# Altium schematic sheet Buttons.SchDoc(Buttons)
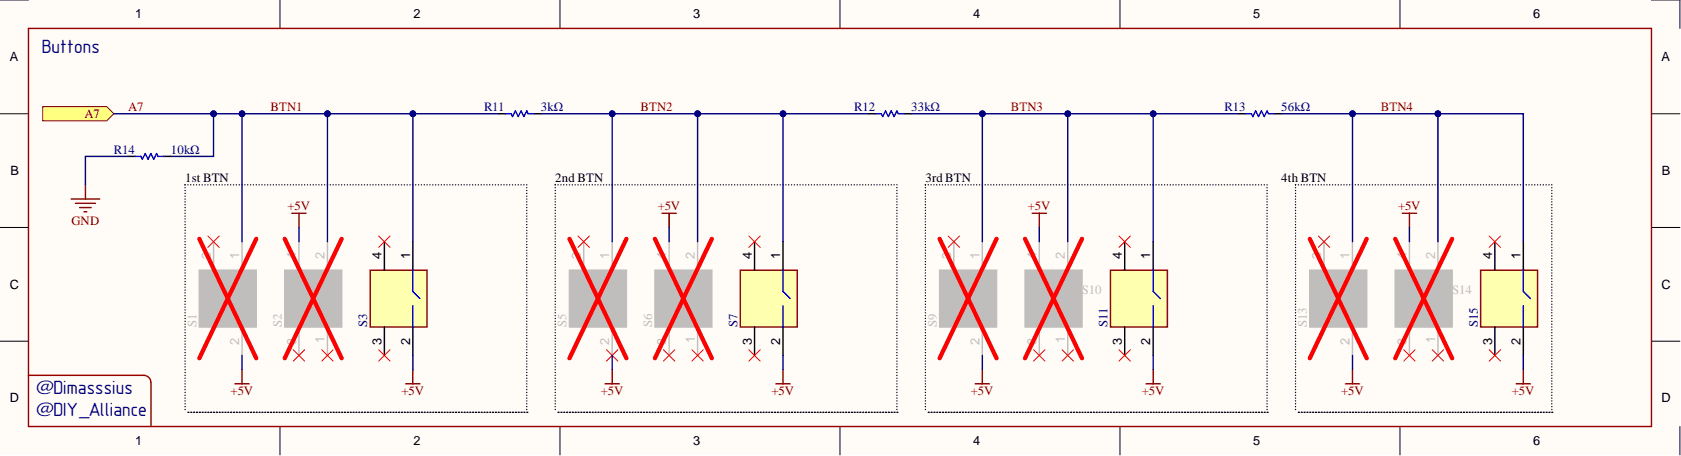
[diagram p0: Buttons.SchDoc]

## Parts
R11  3kΩ  [RES_THD/SMD_R0603_R0402_3K_THD]
R11
R11
R12  33kΩ  [RES_THD/SMD_R0603_R0402_33K_THD]
R12
R12
R13  56kΩ  [RES_THD/SMD_R0603_R0402_56K_THD]
R13
R13
R14  10kΩ  [RES_THD/SMD_R0603_R0402_10K_THD]
R14
R14
S1  TS-1009B  [TS-1009B]  (value not drawn)
S2  TS-1187A-B-A-B  [TS-1187A-B-A-B]  (value not drawn)
S3  EVQPF108K  [EVQPF008K]  (value not drawn)
S5  TS-1009B  [TS-1009B]  (value not drawn)
S6  TS-1187A-B-A-B  [TS-1187A-B-A-B]  (value not drawn)
S7  EVQPF108K  [EVQPF008K]  (value not drawn)
S9  TS-1009B  [TS-1009B]  (value not drawn)
S10  TS-1187A-B-A-B  [TS-1187A-B-A-B]  (value not drawn)
S11  EVQPF108K  [EVQPF008K]  (value not drawn)
S13  TS-1009B  [TS-1009B]  (value not drawn)
S14  TS-1187A-B-A-B  [TS-1187A-B-A-B]  (value not drawn)
S15  EVQPF108K  [EVQPF008K]  (value not drawn)

## Ports
A7

## Nets
+5V: S1.2 S2.4 S3.2 S5.2 S6.4 S7.2 S9.2 S10.4 S11.2 S13.2 S14.4 S15.2
GND: R14.2
A7: R11.2 R14.1 S1.1 S2.2 S3.1 ; port A7
BTN2: R11.1 R12.2 S5.1 S6.2 S7.1
BTN3: R12.1 R13.2 S9.1 S10.2 S11.1
BTN4: R13.1 S13.1 S14.2 S15.1

Not connected: S1 3; S2 1 3; S3 3 4; S5 3; S6 1 3; S7 3 4; S9 3; S10 1 3; S11 3 4; S13 3; S14 1 3; S15 3 4

## Library data of the parts
R11 = RES_THD/SMD_3KΩ_R0603_R0402_THD: Resistor, Metal Film Resistor, Through Hole, D2.3xL6.5mm, 3kΩ, ±1%, 250mW, Through Hole Resistors, ROHS; Component Kind: Standard; Library Name: Resistors.SchLib; Value: 3kΩ
R12 = RES_THD/SMD_33KΩ_R0603_R0402_THD: Resistor, Metal Film Resistor, Through Hole, D2.3xL6.5mm, 33kΩ, ±1%, 250mW, Through Hole Resistors, ROHS; Component Kind: Standard; Library Name: Resistors.SchLib; Value: 33kΩ
R13 = RES_THD/SMD_56KΩ_R0603_R0402_THD: Resistor, Metal Film Resistor, Through Hole, D2.3xL6.5mm, 56kΩ, ±1%, 250mW, Through Hole Resistors, ROHS; Component Kind: Standard; Library Name: Resistors.SchLib; Value: 56kΩ
R14 = RES_THD/SMD_10KΩ_R0603_R0402_THD: Resistor, Metal Film Resistor, Through Hole, D2.3xL6.5mm, 10kΩ, ±1%, 250mW, Through Hole Resistors, ROHS; Component Kind: Standard; Library Name: Resistors.SchLib; Value: 10kΩ
S1 S5 S9 S13 = TS-1009B: Switch Tactile, Through Hole, 4.5x4.5mm, Round Button, 50mA, Bend-in 4.5mm, SPST, 12V, Plugin, Tactile Switches, ROHS; Component Kind: Standard; Datasheet Link: https://www.mouser.com/datasheet/2/140/TL59-TL58-346345-1369262.pdf; Height: 5mm; Library Name: SamacSys.SchLib; Value: TS-1009B
S2 S6 S10 S14 = TS-1187A-B-A-B: Switch Tactile, SMD, 5.1x5.1mm, Without bracket, 50mA, 100000 Times, 160gf, 12V, Round, SMD Tactile Switches, ROHS; Component Kind: Standard; Library Name: SamacSys.SchLib; Package: None; Value: TS-1187A-B-A-B
S3 S7 S11 S15 = EVQPF108K: Switch Tactile; Component Kind: Standard; Datasheet Link: https://datasheet.datasheetarchive.com/originals/distributors/Datasheets-28/DSA-557100.pdf; Height: 7mm; Library Name: SamacSys.IntLib; Value: EVQPF108K
